# Altium schematic sheet mpc56_can.SchDoc(mpc56_can)
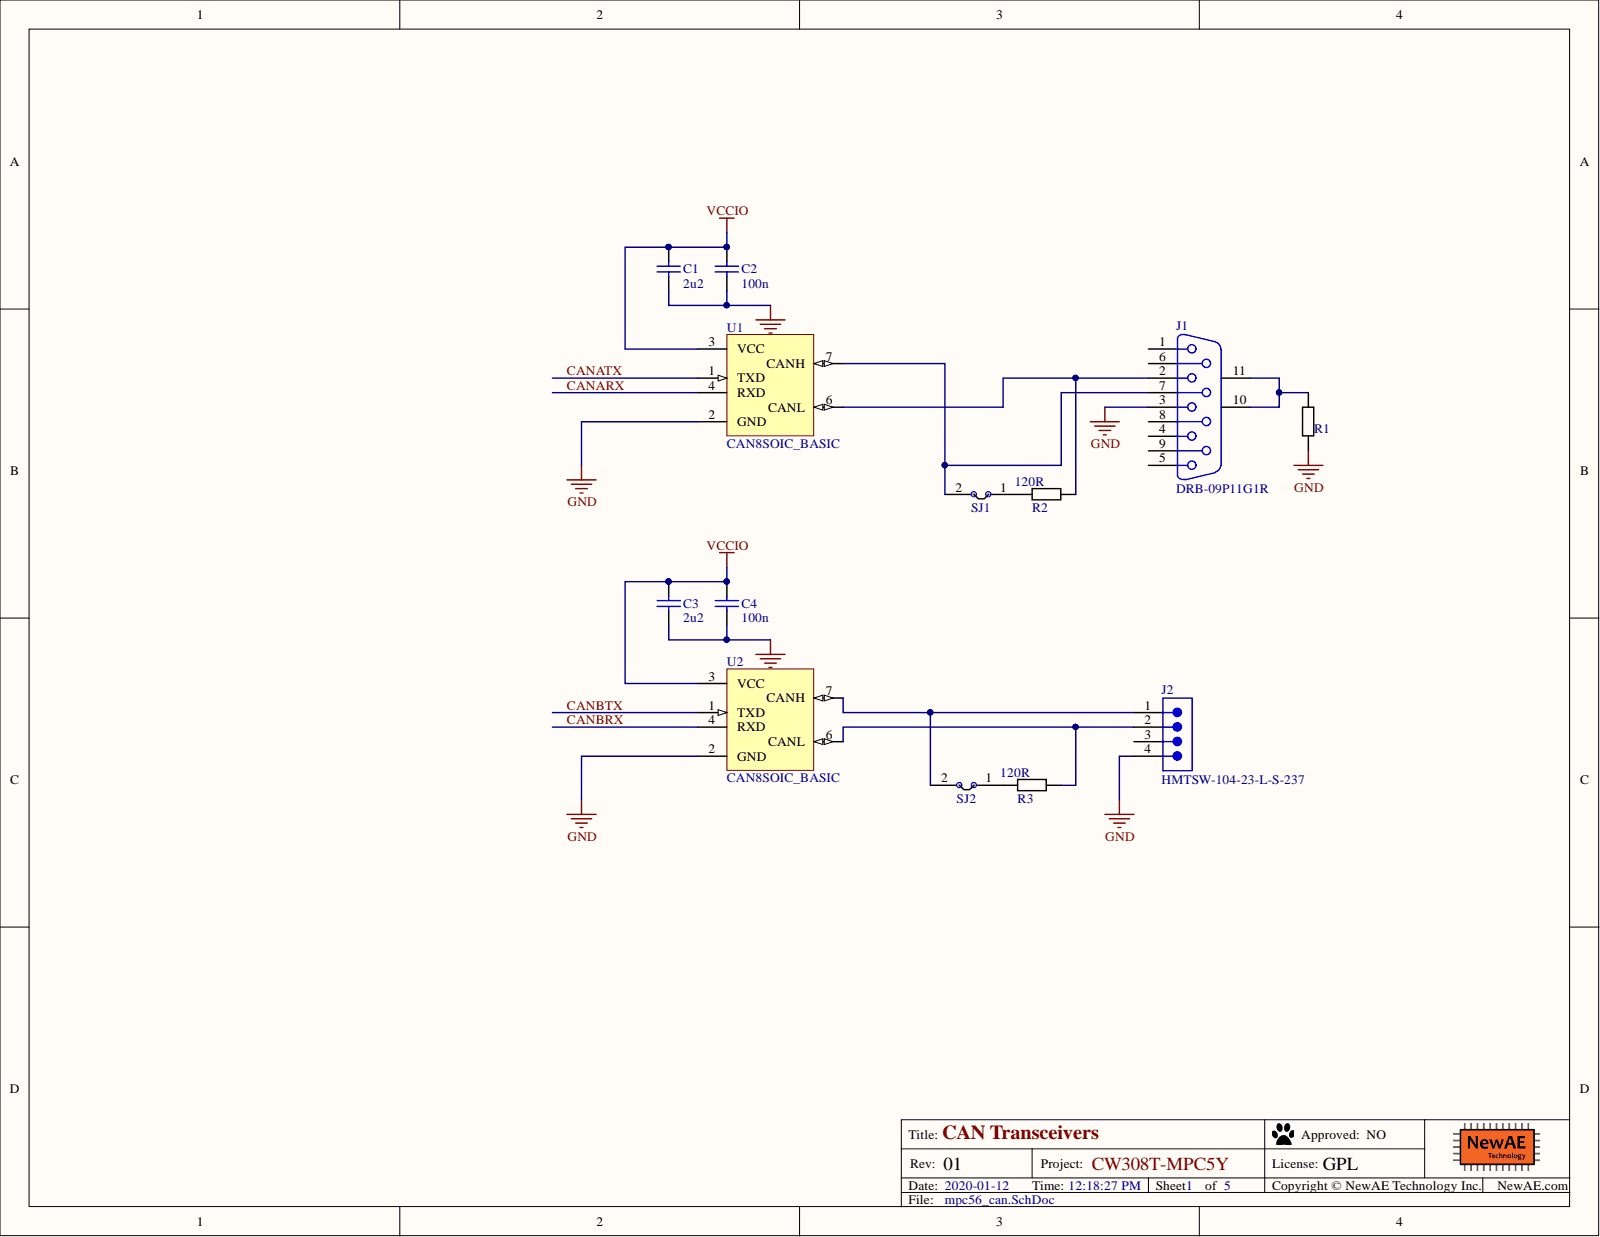
[diagram p0: mpc56_can.SchDoc]

## Parts
C1  2u2  [0603]
C2  100n  [0402]
C3  2u2  [0603]
C4  100n  [0402]
J1  DRB-09P11G1R  [TAIT-DRB-09P11G1R_V]
J2  HMTSW-104-23-L-S-237  [SMTC-HMTSW-104-23-X-S-237]
R1  10R  [0603]  (value not drawn)
R2  120R  [0603]
R3  120R  [0603]
SJ1  NC  [SJ_2S_NC]  (value not drawn)  (no BOM)
SJ2  NC  [SJ_2S_NC]  (value not drawn)  (no BOM)
U1  CAN8SOIC_BASIC  [SOIC8]
U2  CAN8SOIC_BASIC  [SOIC8]

## Nets
GND: C1.2 C2.2 C3.2 C4.2 J1.3 J2.4 R1.1 U1.2(GND) U2.2(GND)
VCCIO: C1.1 C2.1 C3.1 C4.1 U1.3(VCC) U2.3(VCC)
CANARX: U1.4(RXD)
CANATX: U1.1(TXD)
CANBRX: U2.4(RXD)
CANBTX: U2.1(TXD)
NetJ1_2: J1.2 R2.2 U1.6(CANL)
NetJ1_7: J1.7 SJ1.2 U1.7(CANH)
NetJ1_10: J1.10 J1.11 R1.2
NetJ2_1: J2.1 SJ2.2 U2.7(CANH)
NetJ2_2: J2.2 R3.2 U2.6(CANL)
NetR2_1: R2.1 SJ1.1
NetR3_1: R3.1 SJ2.1

Not connected: J1 1 4 5 6 8 9; J2 3

## Library data of the parts
C1 C3 = CCHIP-2u2-0603: 2u2, 0603; Database Table Name: main; Case-Metric: 1608; Value: 2u2; Pin Count: 2; Part Number: CCHIP-2u2-0603; Mounting Technology: SMT; Library Name: NewAE_AltiumLib.DBLib; Component Type: Standard; Component Kind: Standard; Case-EIA: 0603
C2 C4 = CCHIP-100n-0402: 100n, 0402; Database Table Name: main; Value: 100n; Pin Count: 2; Part Number: CCHIP-100n-0402; Mounting Technology: SMT; Library Name: NewAE_AltiumLib.DBLib; Component Type: Standard; Component Kind: Standard; Case-EIA: 0402
J1 = CMP-0264-00001-1: DB9 R/A Connector, Through-hole, Male; PartNumber: DRB-09P11G1R; PackageReference: DRB-09P11G1R; PackageDescription: 9-Pin D-Sub R/A  Male Connector, 2.77 mm Pitch; Mounting Technology: Through Hole; Library Name: Altium Content Vault; ComponentLink2URL: http://www.taitek.com/connects_prod_info.asp?id=A100011; ComponentLink2Description: Datasheet; ComponentLink1URL: http://www.taitek.com/; ComponentLink1Description: Manufacturer URL; Component Kind: Standard
J2 = CMP-1024-00020-1: Manufacturer: Samtec; Pitch: 2.54 mm; Temperature Range: -55 to 125 degC; RoHS: True; PartNumber: HMTSW-104-23-L-S-237; PackageVersion: AL, 3/1987; PackageReference: HMTSW-104-23-X-S-237; PackageDescription: Assembly .025 [.64] SQ. Post Hi-Temp Terminal Strip; Orientation: Vertical; Mounting Technology: Through-hole; Library Name: Altium Content Vault; DatasheetVersion: F-214; ComponentLink3URL: http://www.samtec.com/documents/webfiles/cpdf/HMTSW-XXX-XX-X-X-XXXX-XX-XX-MKT1.pdf; ComponentLink3Description: Package Specification; ComponentLink2URL: http://www.samtec.com/documents/webfiles/pdf/HMTSW.PDF; ComponentLink2Description: Datasheet; ComponentLink1URL: http://www.samtec.com; ComponentLink1Description: Manufacturer URL; Component Kind: Standard
R1 = RCHIP-10R-0603: 10R res, 0603, 1%, 1/10W; Manufacture Part Number 1: RC0603FR-0710RL; Manufacture 1: Yageo; Rated Voltage: 75VDC; Rated Power: 0.1W; Database Table Name: main; Case-Metric: 1608; Value: 10R; Tolerance: 1%; Temperature Range: -55C to 155C; Supplier Part Number 1: 311-10.0HRCT-ND; Supplier 1: Digi-Key; Pin Count: 2; Part Number: RCHIP-10R-0603; Mounting Technology: SMT; Library Name: NewAE_AltiumLib.DBLib; Component Type: Standard; Component Kind: Standard; Case-EIA: 0603
R2 R3 = RCHIP-120R-0603: 120R res, 0603, 1%, 1/10W; Manufacture Part Number 1: RC0603FR-07120RL; Manufacture 1: Yageo; Rated Voltage: 75VDC; Rated Power: 0.1W; Database Table Name: main; Case-Metric: 1608; Value: 120R; Tolerance: 1%; Temperature Range: -55C to 155C; Supplier Part Number 1: 311-120HRCT-ND; Supplier 1: Digi-Key; Pin Count: 2; Part Number: RCHIP-120R-0603; Mounting Technology: SMT; Library Name: NewAE_AltiumLib.DBLib; Component Type: Standard; Component Kind: Standard; Case-EIA: 0603
SJ1 SJ2 = SJ_2S: Solder Jumper, NC; Database Table Name: main; Pin Count: 2; Part Number: SJ_2S; Library Name: NewAE_AltiumLib.DBLib; Component Type: Standard (No BOM)
U1 U2 = SN65HVD232DR: CAN Transceiver, 3.3V; Manufacture 1: Texas Instruments; Database Table Name: main; Component Group: Digital IC; Supplier Part Number 1: 296-26344-1-ND; Supplier 1: Digi-Key; RoHS: Yes; Pin Count: 8; Part Number: SN65HVD232DR; Mounting Technology: SMT; Manufacture Part Number 1: SN65HVD232DR; Library Name: NewAE_AltiumLib.DBLib; Component Type: Standard; Component Kind: Standard
